# Altium schematic sheet wls2-battery.SchDoc(wls2-battery)
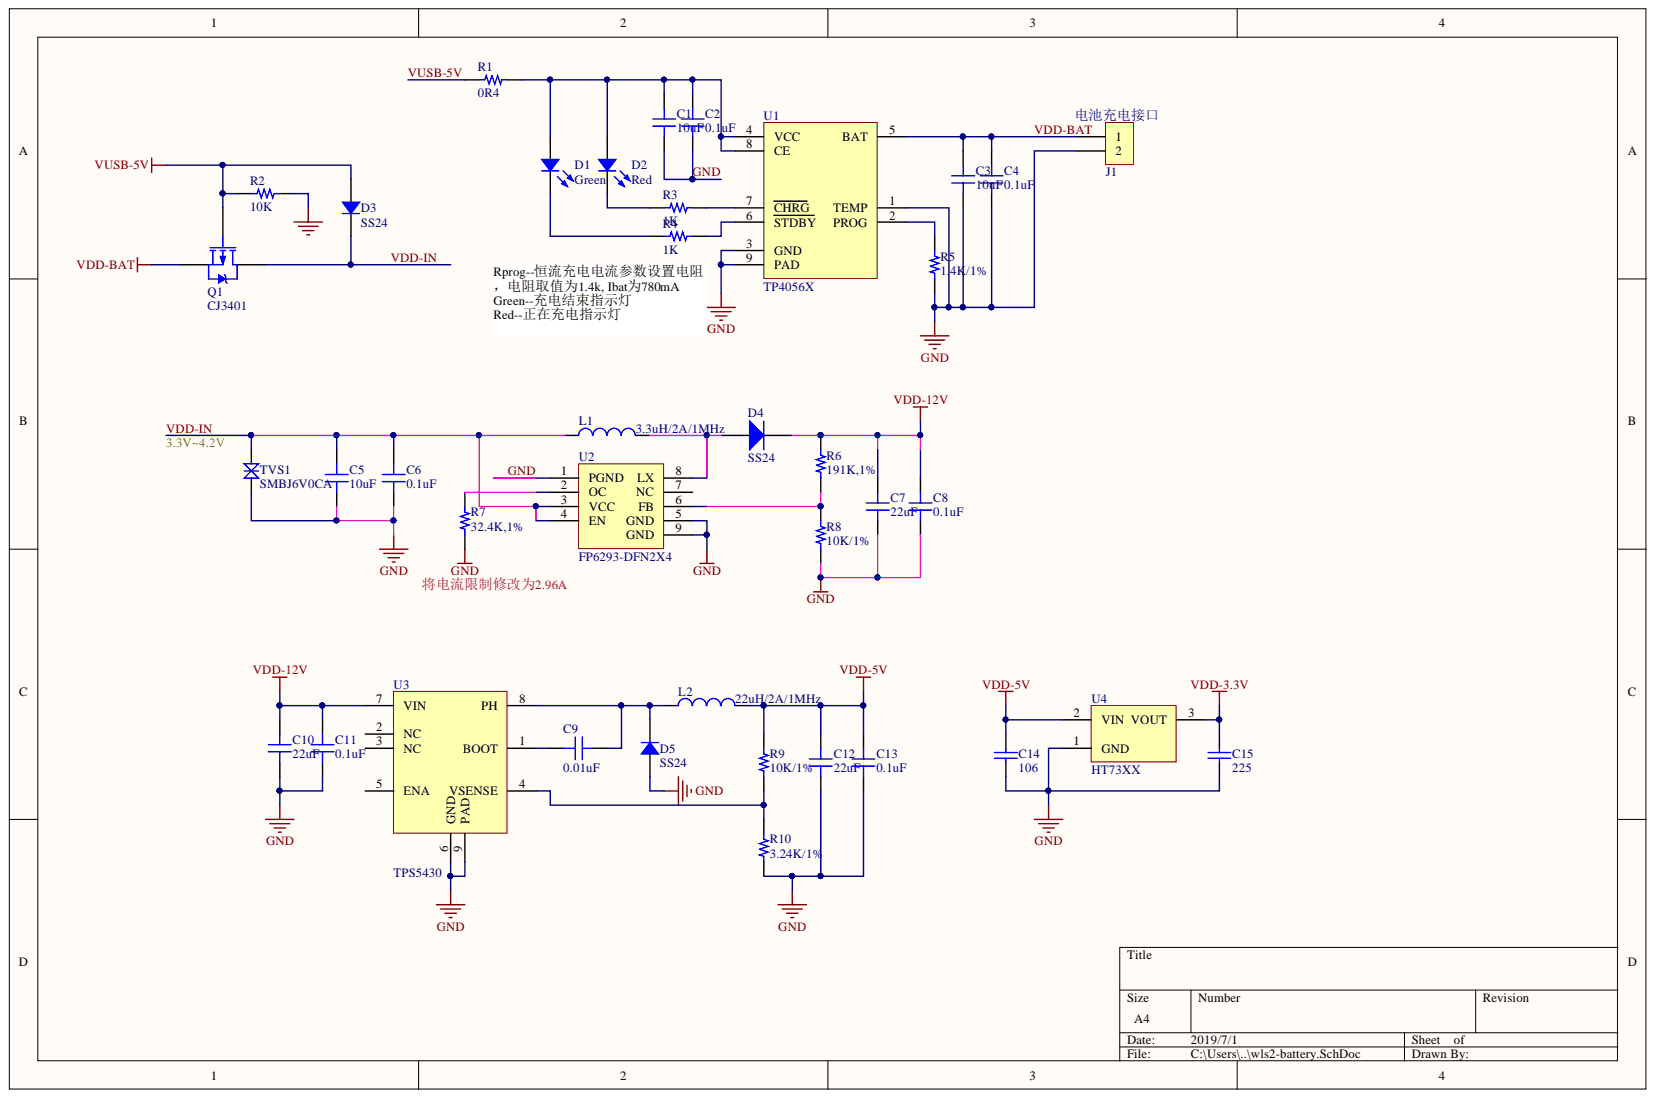
[diagram p0: wls2-battery.SchDoc]

## Parts
C1  10uF  [B0805C]
C2  0.1uF  [RES 0402/1005]
C3  10uF  [B0805C]
C4  0.1uF  [RES 0402/1005]
C5  10uF  [B0805C]
C6  0.1uF  [RES 0402/1005]
C7  22uF  [B1210R]
C8  0.1uF  [B0805C]
C9  0.01uF  [RES 0402/1005]
C10  22uF  [B1210R]
C11  0.1uF  [B0805C]
C12  22uF  [B1210R]
C13  0.1uF  [RES 0402/1005]
C14  value 106  [B1210R]  (hidden comment: Cap Semi)
C15  value 225  [B0603C]  (hidden comment: Cap Semi)
D1  Green  [LED 0805/2012]
D2  Red  [LED 0805/2012]
D3  SS24  [SMB-DO214AA]
D4  SS24  [SMB-DO214AA]
D5  SS24  [SMB-DO214AA]
J1  电池充电接口  [PH2.0-2PIN_JST]
L1  value 3.3uH/2A/1MHz  [STWNR4018]  (hidden comment: Inductor)
L2  value 22uH/2A/1MHz  [STWNR4018]  (hidden comment: Inductor)
Q1  CJ3401  [SOT23]
R1  0R4  [RES 0402/1005]
R2  10K  [RES 0402/1005]
R3  1K  [RES 0402/1005]
R4  1K  [RES 0402/1005]
R5  1.4K/1%  [RES 0402/1005]
R6  191K,1%  [RES 0402/1005]
R7  32.4K,1%  [RES 0402/1005]
R8  10K/1%  [RES 0402/1005]
R9  10K/1%  [RES 0402/1005]
R10  3.24K/1%  [RES 0402/1005]
TVS1  SMBJ6V0CA  [DO214-AA]
U1  TP4056X  [TP4056X-3]
U2  FP6293-DFN2X4  [SOIC127P6]
U3  TPS5430
U4  HT73XX  [SOT89-HT33xx]

## Nets
VDD-3.3V: C15.2 U4.3
VDD-5V: C12.1 C13.1 C14.2 L2.2 R9.2 U4.2
VDD-12V: C7.1 C8.1 C10.1 C11.1 D4.2 R6.2 U3.7(VIN)
GND: C1.1 C2.1 C3.2 C4.2 C5.2 C6.2 C7.2 C8.2 C10.2 C11.2 C12.2 C13.2 C14.1 C15.1 D5.1 J1.2 R2.1 R5.1 R7.1 R8.1 R10.1 TVS1.A U1.1(TEMP) U1.3(GND) U1.9(PAD) U2.1(PGND) U2.5(GND) U2.9(GND) U3.6(GND) U3.9(PAD) U4.1
VDD-BAT: C3.1 C4.1 J1.1 Q1.2 U1.5(BAT)
VDD-IN: C5.1 C6.1 D3.2 L1.1 Q1.3 TVS1.K U2.3(VCC) U2.4(EN)
VUSB-5V: D3.1 Q1.1 R1.1 R2.2
NetC1_2: C1.2 C2.2 D1.1 D2.1 R1.2 U1.4(VCC) U1.8(CE)
NetC9_1: C9.1 U3.1(BOOT)
NetC9_2: C9.2 D5.2 L2.1 U3.8(PH)
NetD1_2: D1.2 R4.2
NetD2_2: D2.2 R3.2
NetD4_1: D4.1 L1.2 U2.8(LX)
NetR3_1: R3.1 U1.7(CHRG)
NetR4_1: R4.1 U1.6(STDBY)
NetR5_2: R5.2 U1.2(PROG)
NetR6_1: R6.1 R8.2 U2.6(FB)
NetR7_2: R7.2 U2.2(OC)
NetR9_1: R9.1 R10.2 U3.4(VSENSE)

Not connected: U2 7(NC); U3 2(NC) 3(NC) 5(ENA)

## Library data of the parts
C1-C13 = Capacitor: SMT Capacitor; Component Kind: Standard; Library Name: Passives.SchLib
  C1 C3 C5: Value: 10uF
  C2 C4 C6 C8 C11 C13: Value: 0.1uF
  C7 C10 C12: Value: 22uF
  C9: Value: 0.01uF
C14 C15 = Cap Semi: Capacitor (Semiconductor SIM Model); Component Kind: Standard; LatestRevisionDate: 29-May-2009; LatestRevisionNote: IPC-7351 Footprint Added.; Library Name: Miscellaneous Devices.IntLib; PackageDescription: Chip Capacitor; PackageReference: C1206; Published: 8-Jun-2000; Signal Integrity: Cap Semi; Simulation: CAP; Publisher: Altium Limited
D1 D2 = LED: Chip LED; Component Kind: Standard; Library Name: Diodes.SchLib
  D1: Value: Green
  D2: Value: Red
D3 D5 = Diode: Rectifier Diode; Component Kind: Standard; Library Name: Diodes.SchLib; Part Number: SS24
D4 = Diode 1N4007: 1 Amp General Purpose Rectifier; Code_JEDEC: DO-204-AL; Component Kind: Standard; ComponentLink1Description: Manufacturer Link; ComponentLink1URL: http://www.fairchildsemi.com/; ComponentLink2Description: Datasheet; ComponentLink2URL: http://www.fairchildsemi.com/ds/1N%2F1N4001.pdf; DatasheetDocument: 1998; LatestRevisionDate: 17-Jul-2002; LatestRevisionNote: Re-released for DXP Platform.; Library Name: Miscellaneous Devices.IntLib; PackageDocument: Aug-1999; PackageReference: DO-41; Published: 8-Jun-2000; Signal Integrity: 1N4007; Simulation: 1N4007; Publisher: Altium Limited
J1 = PH2.0-2V: PH系列直插垂直针座接插件，2.0mm间距，单排3位; Component Kind: Standard; Package: PH2.0-2V; Value: PH2.0-2V; Library Name: yzj_device_sch_lib.SchLib
L1 L2 = Inductor: Code_IEC: 1005; Code_JEITA: 0402; Component Kind: Standard; Description: Inductor; LatestRevisionDate: 17-Jul-2002; LatestRevisionNote: Re-released for DXP Platform.; Library Name: Miscellaneous Devices.IntLib; PackageReference: 0402-A; Published: 8-Jun-2000; Signal Integrity: Inductor; Simulation: INDUCTOR; Publisher: Altium Limited
Q1 = PMOS: Component Kind: Standard; Library Name: Footprint.SchLib
R1-R10 = Resistor: SMT Resistor; Component Kind: Standard; Library Name: Passives.SchLib
  R1: Value: 0R4
  R2: Value: 10K
  R3 R4: Value: 1K
  R5: Value: 1.4K/1%
  R6: Value: 191K,1%
  R7: Value: 32.4K,1%
  R8 R9: Value: 10K/1%
  R10: Value: 3.24K/1%
TVS1 = TVS: Component Kind: Standard; Library Name: Footprint.SchLib
U2 = FP6293: Component Kind: Standard; Description: FP6293; Library Name: device_sch_lib.SchLib
U4 = HT73XX-SOT89A: Component Kind: Standard; Library Name: Footprint.SchLib
U1 = TP4056X: Component Kind: Standard; Description: TP4056X; Library Name: device_sch_lib.SchLib
U3 = TPS5430: Component Kind: Standard; Library Name: Footprint.SchLib
